# Altium schematic sheet ESC.SchDoc
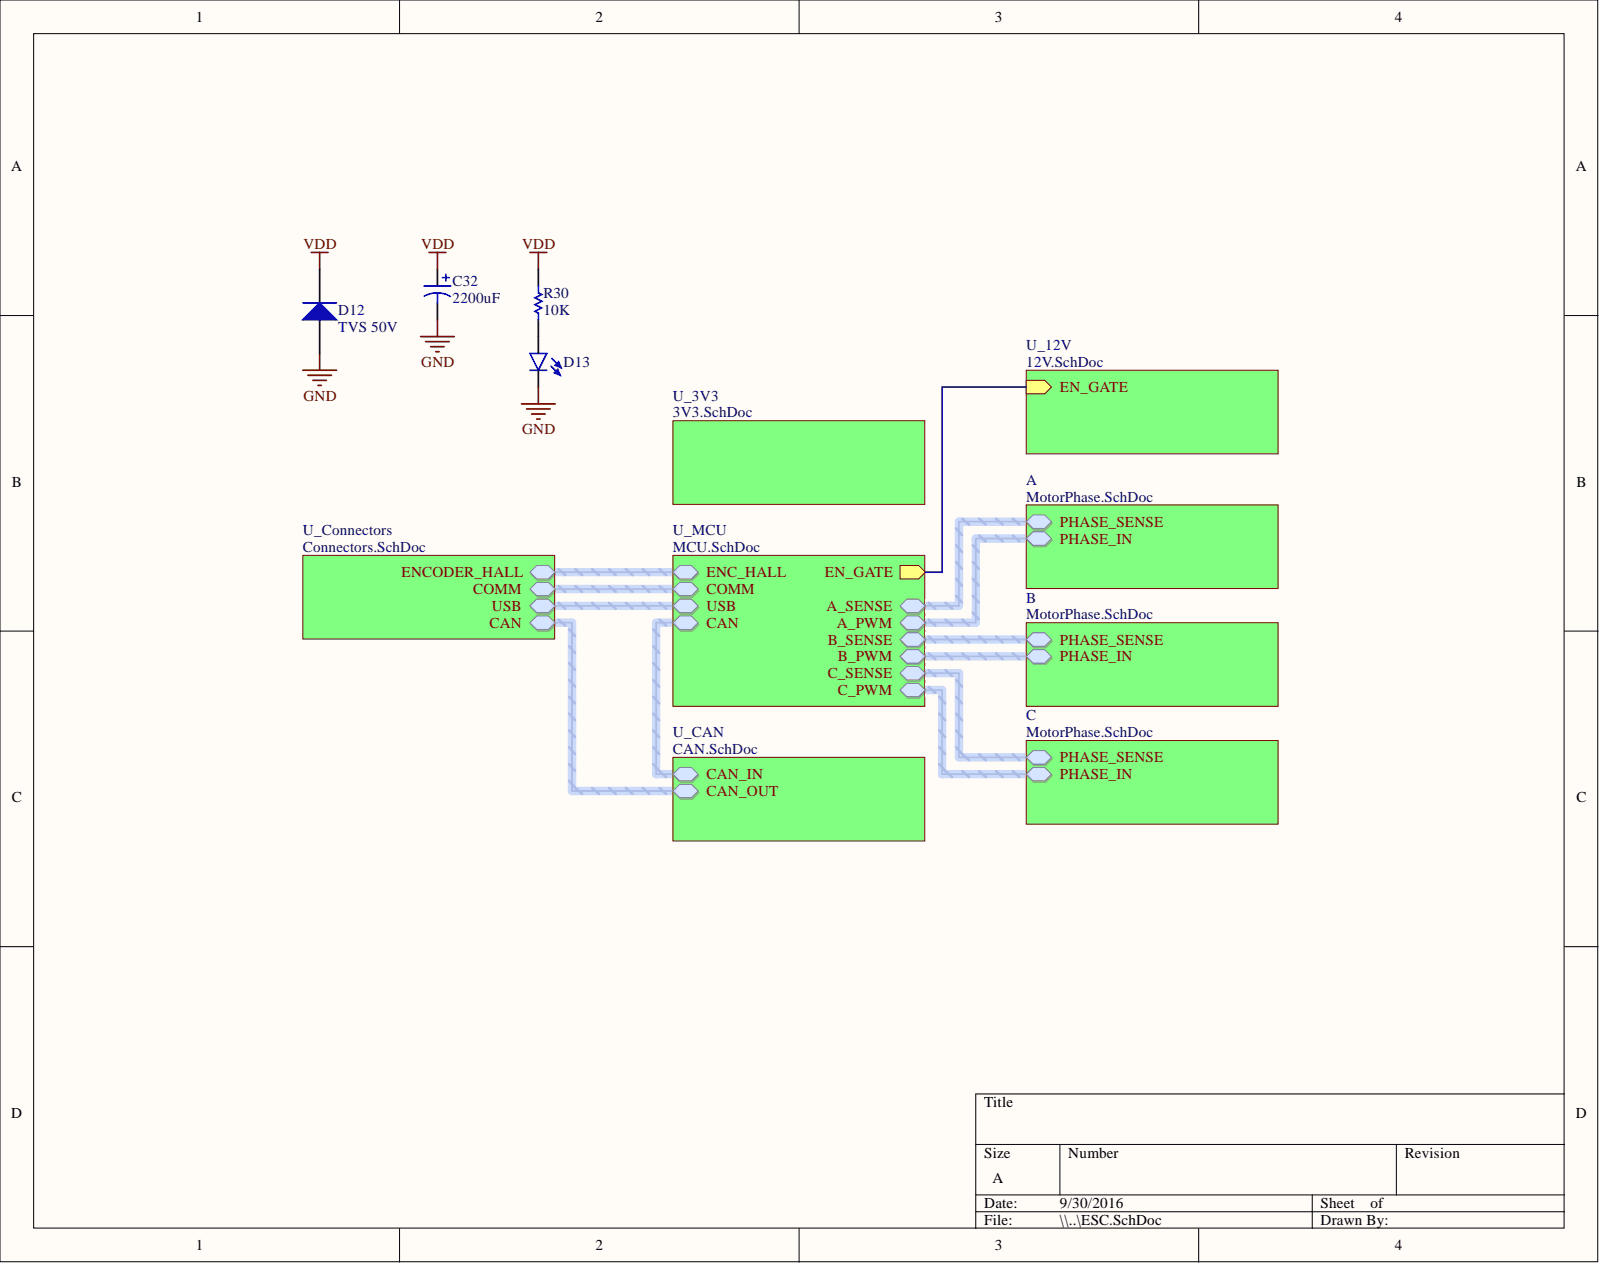
[diagram p0: ESC.SchDoc]

## Parts
C32  value 2200uF  [CAP-RADIAL-HORZ [18x35.5]]  (hidden comment: CAP 2200uF)
D12  TVS 50V  [VISH-DO-214AC-2_N]
D13  LED-BLUE  [LED-0603]  (value not drawn)
R30  value 10K  [R0603[1608]]  (hidden comment: 10K 5% 0603)

## Nets
GND: C32.2 D12.2 D13.C
VDD: C32.1 D12.1 R30.2
NetD13_A: D13.A R30.1
CAN_IN.RX:
CAN_IN.TX:
CAN_OUT.CANH:
CAN_OUT.CANL:
COMM.MISO:
COMM.MOSI_PPM:
COMM.RX_SDA_CS:
COMM.TX_SCL_SCK:
EN_GATE:
ENCODER_HALL.CS_TEMP:
ENCODER_HALL.MISO_H2_B:
ENCODER_HALL.MOSI_H1_A:
ENCODER_HALL.SCK_H3_I:
PHASE_IN.HI:
PHASE_IN.LO:
PHASE_SENSE.CURR_SENSE:
PHASE_SENSE.VSENSE:
USB.DM:
USB.DP:

## Library data of the parts
C32 = CAP 2200uF: Manufacturer: Nichicon; PartNumber: UVR1J222MHD; Tolerance: 20%; Voltage: 63V; Component Kind: Standard; Library Name: Components.SchLib; Mounting Technology: Through Hole; PackageDescription: Radial Capacitor,18x35.5mm, Lead Spacing 7.5mm; PackageReference: Radial Capacitor,18x35.5mm; Simulation: CAP
D12 = CMP-0548-00253-1: Transient Voltage Suppressor, 6.40 to 231 V, 45 V Stand-off Voltage, Uni-Directional, -55 to 155 degC, 2-pin DO-214AC, Pb-Free, Tape and Reel; Manufacturer: Vishay Semiconductor; PartNumber: SMAJ51A-E3/61; ID (uA): 1; IFSM: 40 A; PPPM (W): 400, 300; VBR Uni-Directional (V): 6.4 to 231; VWM (V): 51; Component Kind: Standard; ComponentLink1Description: Manufacturer URL; ComponentLink1URL: http://www.vishay.com/; ComponentLink2Description: Datasheet; ComponentLink2URL: http://www.vishay.com/docs/88390/smaj50a.pdf; ComponentLink3Description: Package Specification; ComponentLink3URL: http://www.vishay.com/docs/88390/smaj50a.pdf; DatasheetVersion: 12/8/2013; Library Name: Altium Content Vault; Mounting Technology: Surface Mount; Operating Junction and Storage Temperature Range: -55 to 150 degC; PackageDescription: 2-Pin DO-214AC (SMA), Body 4.245 x 2.665 mm; PackageReference: DO-214AC-2; Packing: Tape and Reel; RoHS: TRUE
D13 = LED_0603: Manufacturer: Lite-On Inc.; PartNumber: LTST-C193TBKT-5A; Component Kind: Standard; Library Name: LEDs.IntLib; Mounting Technology: Surface Mount; PackageDescription: 0603 (1608 Metric); PackageReference: 0603
R30 = RES_10K_0603_5%: 10K 0.25W 5% 0603 (1005 Metric); Manufacturer: Vishay; PartNumber: RCS060310K0JNEA; Component Kind: Standard; ComponentLink1Description: Datasheet; ComponentLink1URL: http://www.vishay.com/docs/20065/rcse3.pdf; Library Name: Resistors.IntLib; Mounting Technology: Surface Mount; PackageDescription: 0603 (1608 Metric); PackageReference: 0603; Power: 0.25W; Tolerance: 5%
